# Altium schematic sheet P06_Boot.SchDoc(P06_Boot)
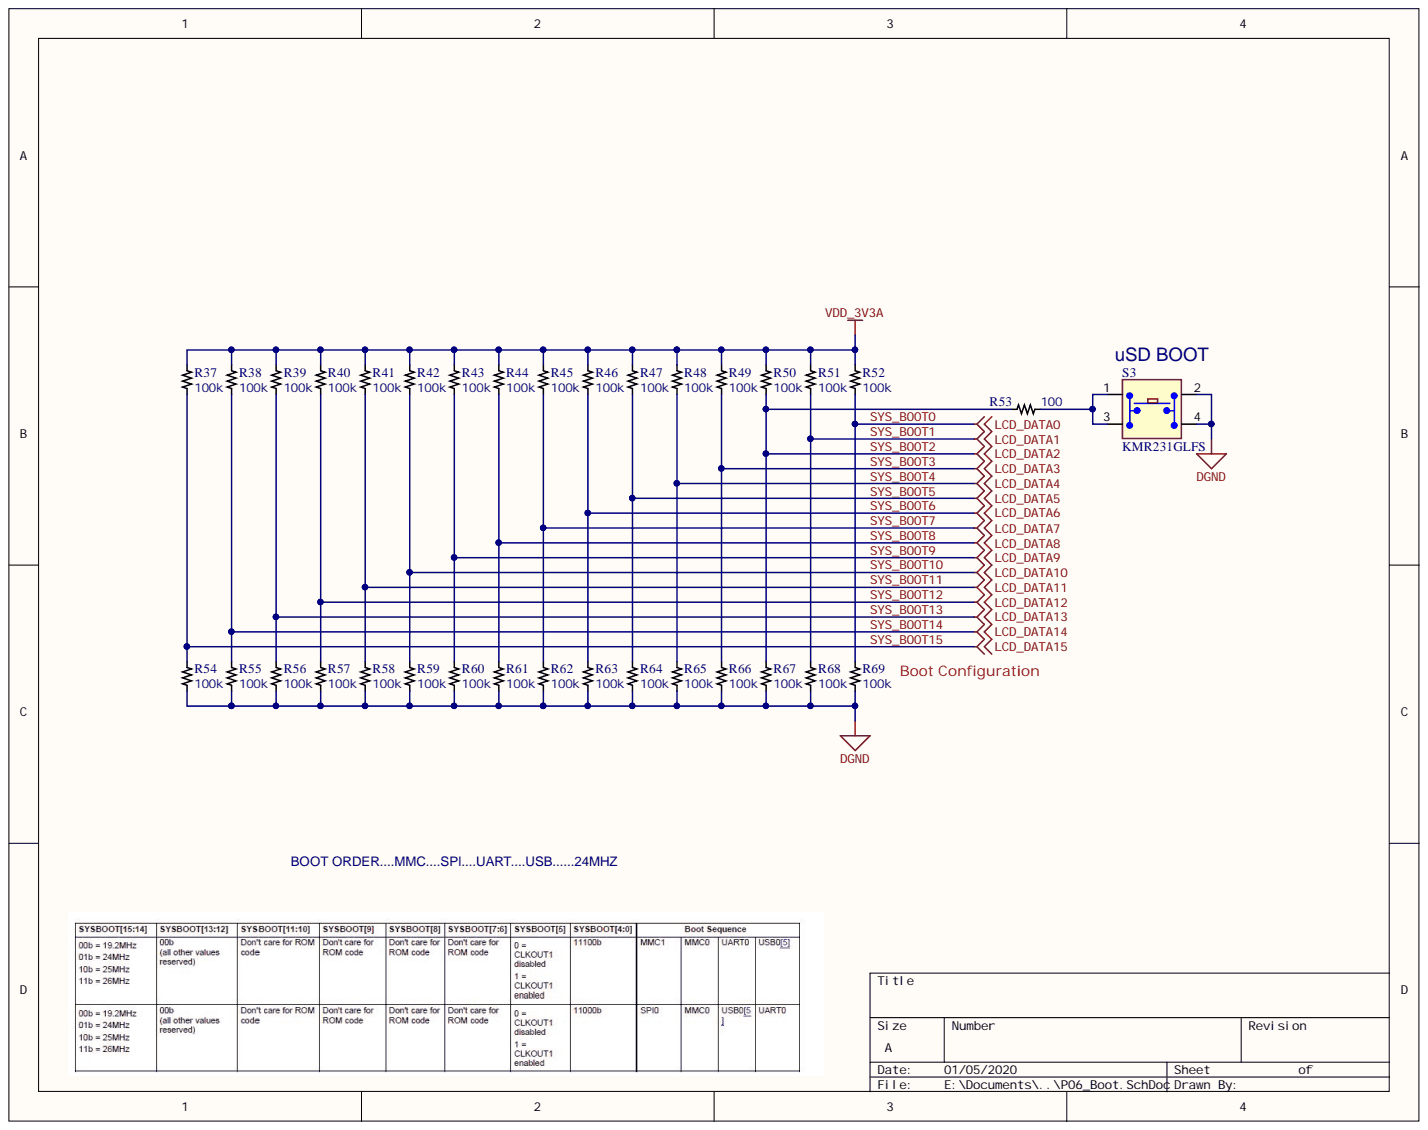
[diagram p0: P06_Boot.SchDoc]

## Parts
R37  Resistance: 100k  [RES0402]  (hidden comment: RC0402FR-07100KL)
R38  Resistance: 100k  [RES0402]  (hidden comment: RC0402FR-07100KL)
R39  Resistance: 100k  [RES0402]  (hidden comment: RC0402FR-07100KL)
R40  Resistance: 100k  [RES0402]  (hidden comment: RC0402FR-07100KL)
R41  Resistance: 100k  [RES0402]  (hidden comment: RC0402FR-07100KL)
R42  Resistance: 100k  [RES0402]  (hidden comment: RC0402FR-07100KL)
R43  Resistance: 100k  [RES0402]  (hidden comment: RC0402FR-07100KL)
R44  Resistance: 100k  [RES0402]  (hidden comment: RC0402FR-07100KL)
R45  Resistance: 100k  [RES0402]  (hidden comment: RC0402FR-07100KL)
R46  Resistance: 100k  [RES0402]  (hidden comment: RC0402FR-07100KL)
R47  Resistance: 100k  [RES0402]  (hidden comment: RC0402FR-07100KL)
R48  Resistance: 100k  [RES0402]  (hidden comment: RC0402FR-07100KL)
R49  Resistance: 100k  [RES0402]  (hidden comment: RC0402FR-07100KL)
R50  Resistance: 100k  [RES0402]  (hidden comment: RC0402FR-07100KL)
R51  Resistance: 100k  [RES0402]  (hidden comment: RC0402FR-07100KL)
R52  Resistance: 100k  [RES0402]  (hidden comment: RC0402FR-07100KL)
R53  RC0402FR-07100RL  [RES0402]  (value not drawn)
R54  Resistance: 100k  [RES0402]  (hidden comment: RC0402FR-07100KL)
R55  Resistance: 100k  [RES0402]  (hidden comment: RC0402FR-07100KL)
R56  Resistance: 100k  [RES0402]  (hidden comment: RC0402FR-07100KL)
R57  Resistance: 100k  [RES0402]  (hidden comment: RC0402FR-07100KL)
R58  Resistance: 100k  [RES0402]  (hidden comment: RC0402FR-07100KL)
R59  Resistance: 100k  [RES0402]  (hidden comment: RC0402FR-07100KL)
R60  Resistance: 100k  [RES0402]  (hidden comment: RC0402FR-07100KL)
R61  Resistance: 100k  [RES0402]  (hidden comment: RC0402FR-07100KL)
R62  Resistance: 100k  [RES0402]  (hidden comment: RC0402FR-07100KL)
R63  Resistance: 100k  [RES0402]  (hidden comment: RC0402FR-07100KL)
R64  Resistance: 100k  [RES0402]  (hidden comment: RC0402FR-07100KL)
R65  Resistance: 100k  [RES0402]  (hidden comment: RC0402FR-07100KL)
R66  Resistance: 100k  [RES0402]  (hidden comment: RC0402FR-07100KL)
R67  Resistance: 100k  [RES0402]  (hidden comment: RC0402FR-07100KL)
R68  Resistance: 100k  [RES0402]  (hidden comment: RC0402FR-07100KL)
R69  Resistance: 100k  [RES0402]  (hidden comment: RC0402FR-07100KL)
S3  KMR231GLFS  [SW4SMD_63X161LS]

## Nets
DGND: R54.1 R55.1 R56.1 R57.1 R58.1 R59.1 R60.1 R61.1 R62.1 R63.1 R64.1 R65.1 R66.1 R67.1 R68.1 R69.1 S3.2 S3.4
VDD_3V3A: R37.2 R38.2 R39.2 R40.2 R41.2 R42.2 R43.2 R44.2 R45.2 R46.2 R47.2 R48.2 R49.2 R50.2 R51.2 R52.2
SYS_BOOT0: R52.1 R69.2
SYS_BOOT1: R51.1 R68.2
SYS_BOOT2: R50.1 R53.1 R67.2
SYS_BOOT3: R49.1 R66.2
SYS_BOOT4: R48.1 R65.2
SYS_BOOT5: R47.1 R64.2
SYS_BOOT6: R46.1 R63.2
SYS_BOOT7: R45.1 R62.2
SYS_BOOT8: R44.1 R61.2
SYS_BOOT9: R43.1 R60.2
SYS_BOOT10: R42.1 R59.2
SYS_BOOT11: R41.1 R58.2
SYS_BOOT12: R40.1 R57.2
SYS_BOOT13: R39.1 R56.2
SYS_BOOT14: R38.1 R55.2
SYS_BOOT15: R37.1 R54.2
NetR53_2: R53.2 S3.1 S3.3

## Library data of the parts
R37-R52 R54-R69 = RES_100k: Resistor 100kOhm 0402 SMD; Manufacturer: Yageo; Working Voltage: 50V; Tolerance: 1%; Temperature Coefficient: 100ppm/°C; Power Rating: 63mW; Width: 0.02inch; Voltage Rating: 50V; Termination: SMD/SMT; Supplier Part Number 2: 311-100KLRCT-ND; Supplier Part Number 1: 603-RC0402FR-07100KL; Supplier 2: Digi-Key; Supplier 1: Mouser; RoHS: Yes; REACH SVHC: No SVHC; Packaging: Tape and Reel; Package Quantity: 1; Number of Terminations: 2; Min Operating Temperature: -55°C; Military Standard: Not; Max Power Dissipation: 63mW; Max Operating Temperature: 155°C; Manufacturer Part Number: RC0402FR-07100KL; Length: 0.039inch; Lead Free: Lead Free; Height: 0.016inch; Features: Moisture Resistant; Depth: 0.5mm; Composition: Thick Film; ComponentLink2URL: https://www.yageo.com/upload/media/product/productsearch/datasheet/rchip/PYu-RC_Group_51_RoHS_L_10.pdf; ComponentLink2Description: Datasheet; Component Kind: Standard; Case Code (Metric): 1005; Case Code (Imperial): 0402; Case/Package: 0402; Library Name: Orient.SchLib
R53 = RES_100: Resistor 100Ohm 0402 SMD; Manufacturer: Yageo; Voltage Rating (DC): 50V; Tolerance: 1%; Temperature Coefficient: 100ppm/°C; Resistance: 100; Power Rating: 62.5mW; Width: 0.5mm; Voltage Rating: 50V; Termination: SMD/SMT; Supplier 1: Digi-Key; Stock 1: 45000776; RoHS: Yes; REACH SVHC: No SVHC; Pricing 1: 1=0.10000, 10=0.01600, 100=0.00640, 1000=0.00289, 2500=0.00251, 5000=0.00207 (USD); Packaging: Tape and Reel; Number of Pins: 2; Min Operating Temperature: -55°C; Military Standard: Not; Max Operating Temperature: 155°C; Manufacturer Part Number: RC0402FR-07100RL; Length: 1mm; Lead Free: Lead Free; Height: 0.32mm; Depth: 0.5mm; Composition: Thick Film; ComponentLink7URL: https://datasheet.ciiva.com/15189/getdatasheetpartid-329466-15189335.pdf?src-supplier=Verical; ComponentLink7Description: https://datasheet.ciiva.com/15189/getdatasheetpartid-329466-15189335.pdf?src-supplier=Verical; ComponentLink6URL: https://datasheet.ciiva.com/16740/pyu-rc0402-51-rohs-l-6-r-16740566.pdf?src-supplier=Future+Electronics; ComponentLink6Description: https://datasheet.ciiva.com/16740/pyu-rc0402-51-rohs-l-6-r-16740566.pdf?src-supplier=Future+Electronics; ComponentLink5URL: https://datasheet.ciiva.com/28367/1018594-28367226.pdf?src-supplier=Element14; ComponentLink5Description: https://datasheet.ciiva.com/28367/1018594-28367226.pdf?src-supplier=Element14; ComponentLink4URL: https://datasheet.ciiva.com/22747/1018594-22747511.pdf?src-supplier=Element14; ComponentLink4Description: https://datasheet.ciiva.com/22747/1018594-22747511.pdf?src-supplier=Element14; ComponentLink3URL: https://datasheet.ciiva.com/12667/pyu-rc0402-51-rohs-l-6-r-12667957.pdf?src-supplier=Mouser; ComponentLink3Description: https://datasheet.ciiva.com/12667/pyu-rc0402-51-rohs-l-6-r-12667957.pdf?src-supplier=Mouser; ComponentLink2URL: https://datasheet.ciiva.com/11808/0900766b80c66297-11808765.pdf?src-supplier=RSComponents; ComponentLink2Description: https://datasheet.ciiva.com/11808/0900766b80c66297-11808765.pdf?src-supplier=RSComponents; ComponentLink1URL: https://datasheet.ciiva.com/7849/1784430-7849810.pdf?src-supplier=Element14; ComponentLink1Description: https://datasheet.ciiva.com/7849/1784430-7849810.pdf?src-supplier=Element14; Component Kind: Standard; Case Code (Metric): 1005; Case Code (Imperial): 0402; Case/Package: 0402; Library Name: Orient.SchLib
S3 = KMR2: Microminiature Tact Switch for SMT; Manufacturer: C&K Components; Switch Travel: 0.25mm; Switch Function: Off-Mom; Outline: 4.60mm x 2.80mm; Operating Force: 300gf; Mechanical Life: 150,000 Cycles; Illumination: Non-Illuminated; Contact Rating @ Voltage: 0.05A @ 32VDC; Circuit: SPST-NO; Actuator Type: Standard; Actuator Orientation: Top Actuated; Actuator Height off PCB, from Pins: 1.90mm; Termination Style: Gull Wing; Supplier Part Number 1: 401-1429-6-ND; Supplier 1: Digi-Key; Stock 1: 32289; RoHS: RoHS Compliant; Pricing 1: 1=0.44, 10=0.416, 25=0.3744, 50=0.3326, 100=0.3188, 250=0.29108, 500=0.2772, 1000=0.22869 (USD); Packaging: Digi-Reel®; Operating Temperature: -40°C ~ 85°C; Mounting Type: Surface Mount; Manufacturer Part Number: KMR231GLFS; Library Name: Orient.SchLib; Ingress Protection: -; Illumination Voltage (Nominal): -; Illumination Type, Color: -; Features: Grounding Pin; ComponentLink1URL: http://www.ck-components.com/index.php?module=media&action=Display&cmpref=14414&lang=en&width=&height=&format=&alt=; ComponentLink1Description: http://www.ck-components.com/index.php?module=media&action=Display&cmpref=14414&lang=en&width=&height=&format=&alt=; Component Kind: Standard; Category: Switches; Actuator Material: -
